# Altium schematic sheet MOTOR_DVR.SchDoc
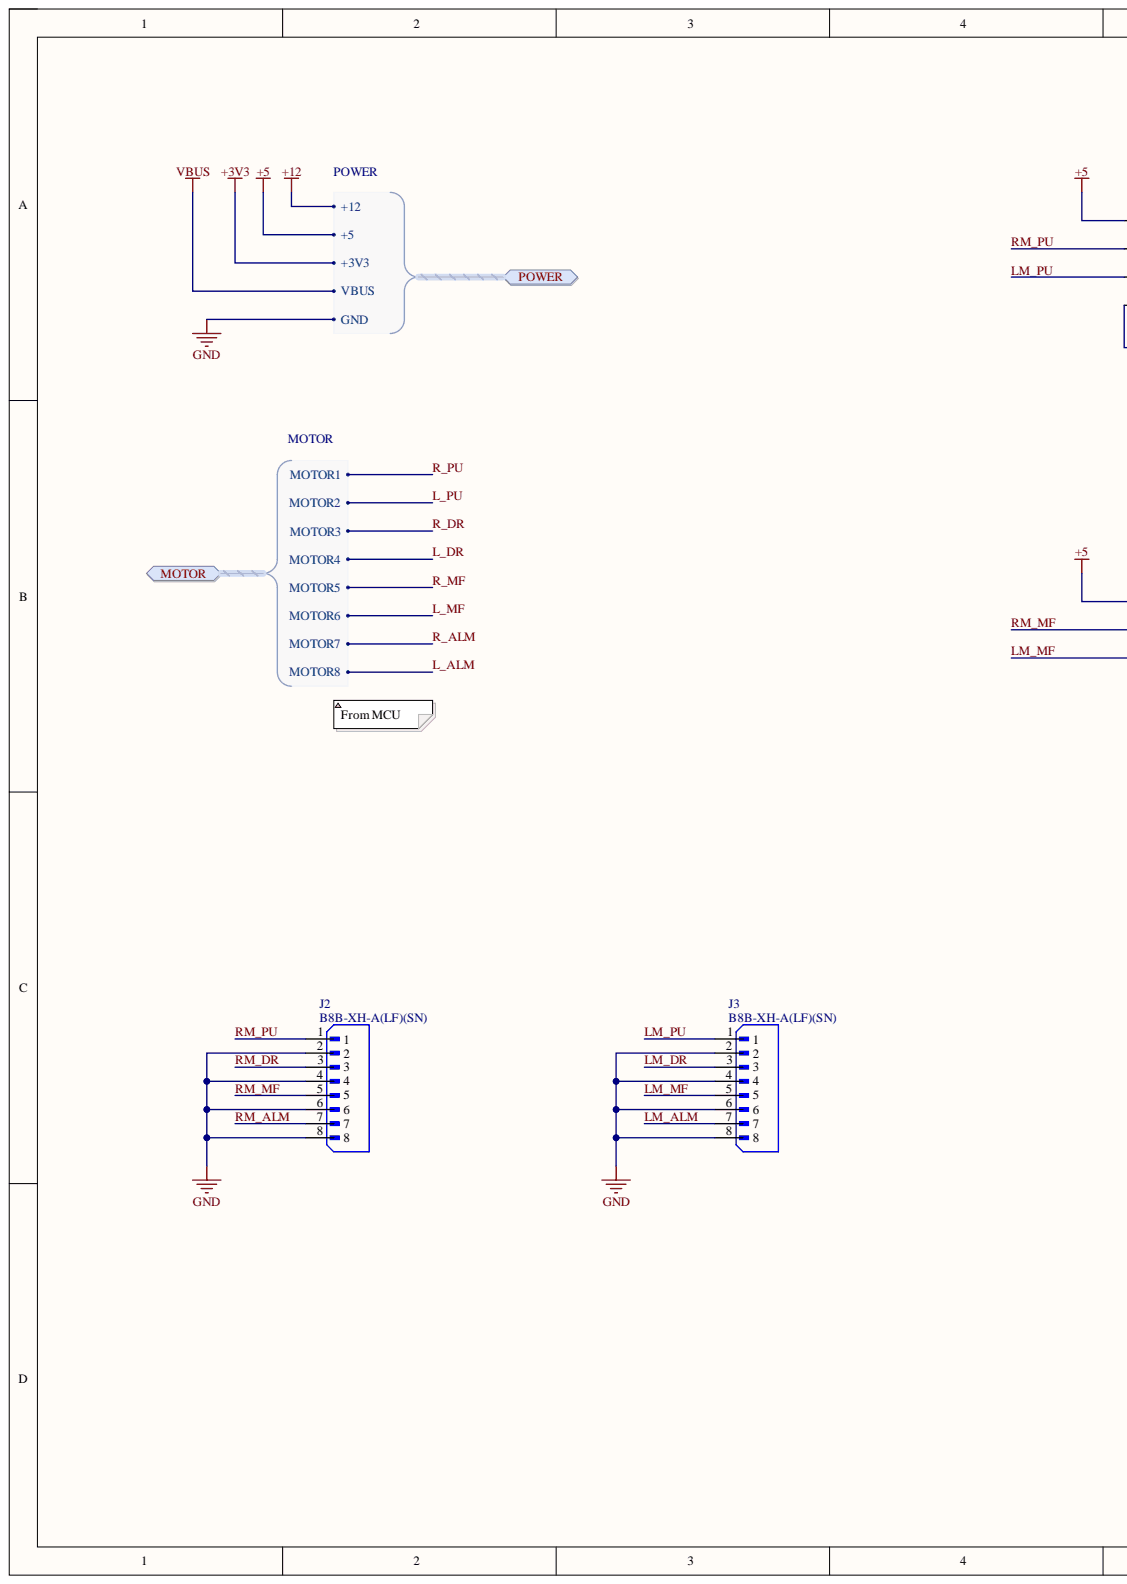
[diagram p0: MOTOR_DVR.SchDoc, left]
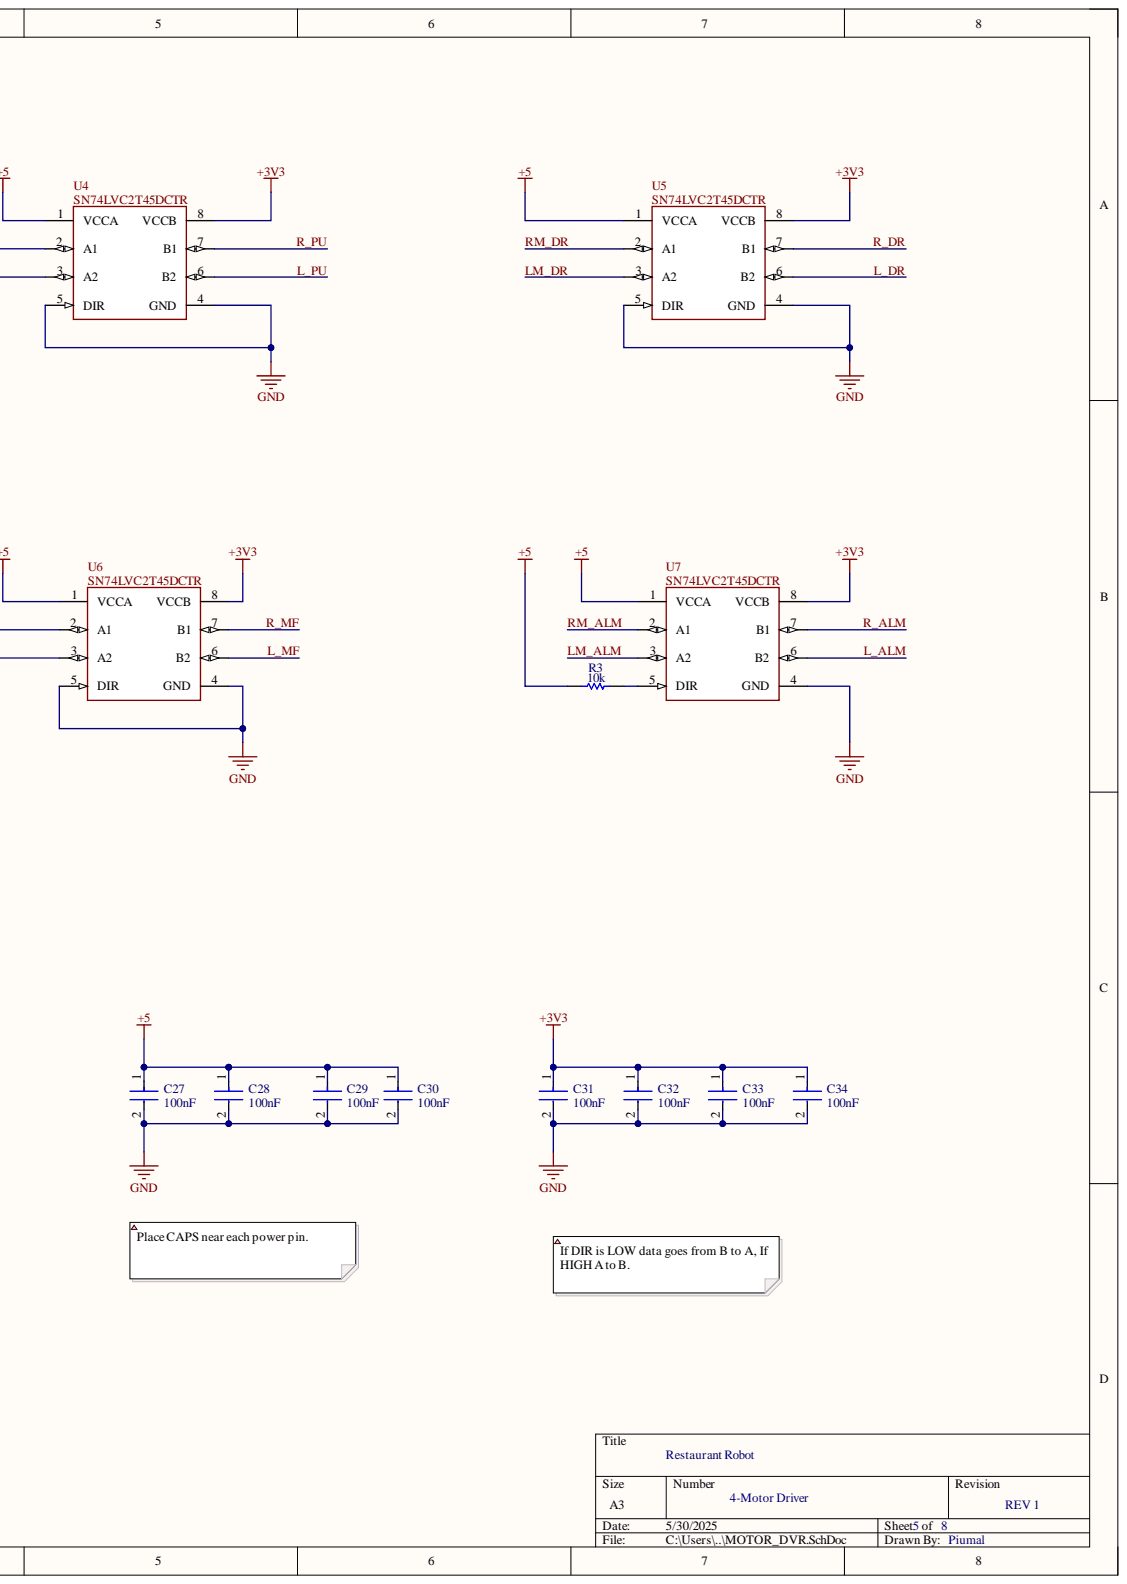
[diagram p1: MOTOR_DVR.SchDoc, right]

## Parts
C27  Capacitance: 100nF  [FP-CL21-IPC_A]  (hidden comment: CL21B104KOANNNC)
C28  Capacitance: 100nF  [FP-CL21-IPC_A]  (hidden comment: CL21B104KOANNNC)
C29  Capacitance: 100nF  [FP-CL21-IPC_A]  (hidden comment: CL21B104KOANNNC)
C30  Capacitance: 100nF  [FP-CL21-IPC_A]  (hidden comment: CL21B104KOANNNC)
C31  Capacitance: 100nF  [FP-CL21-IPC_A]  (hidden comment: CL21B104KOANNNC)
C32  Capacitance: 100nF  [FP-CL21-IPC_A]  (hidden comment: CL21B104KOANNNC)
C33  Capacitance: 100nF  [FP-CL21-IPC_A]  (hidden comment: CL21B104KOANNNC)
C34  Capacitance: 100nF  [FP-CL21-IPC_A]  (hidden comment: CL21B104KOANNNC)
J2  B8B-XH-A(LF)(SN)  [JST_B8B-XH-A(LF)(SN)]
J3  B8B-XH-A(LF)(SN)  [JST_B8B-XH-A(LF)(SN)]
R3  Resistance: 10k  [RESC2013X60X35ML10T20]  (hidden comment: RC0805JR-0710KL)
U4  SN74LVC2T45DCTR  [FP-DCT0008A-MFG]
U5  SN74LVC2T45DCTR  [FP-DCT0008A-MFG]
U6  SN74LVC2T45DCTR  [FP-DCT0008A-MFG]
U7  SN74LVC2T45DCTR  [FP-DCT0008A-MFG]

## Ports
MOTOR, MOTOR.MOTOR1, MOTOR.MOTOR2, MOTOR.MOTOR3, MOTOR.MOTOR4, MOTOR.MOTOR5, MOTOR.MOTOR6, MOTOR.MOTOR7, MOTOR.MOTOR8, POWER, POWER.+3V3, POWER.+5, POWER.+12, POWER.GND, POWER.VBUS

## Nets
+3V3: C31.1 C32.1 C33.1 C34.1 U4.8(VCCB) U5.8(VCCB) U6.8(VCCB) U7.8(VCCB) ; port POWER
+5: C27.1 C28.1 C29.1 C30.1 R3.1 U4.1(VCCA) U5.1(VCCA) U6.1(VCCA) U7.1(VCCA) ; port POWER
GND: C27.2 C28.2 C29.2 C30.2 C31.2 C32.2 C33.2 C34.2 J2.2 J2.4 J2.6 J2.8 J3.2 J3.4 J3.6 J3.8 U4.4(GND) U4.5(DIR) U5.4(GND) U5.5(DIR) U6.4(GND) U6.5(DIR) U7.4(GND) ; port POWER
L_ALM: U7.6(B2) ; port MOTOR
L_DR: U5.6(B2) ; port MOTOR
L_MF: U6.6(B2) ; port MOTOR
L_PU: U4.6(B2) ; port MOTOR
LM_ALM: J3.7 U7.3(A2)
LM_DR: J3.3 U5.3(A2)
LM_MF: J3.5 U6.3(A2)
LM_PU: J3.1 U4.3(A2)
R_ALM: U7.7(B1) ; port MOTOR
R_DR: U5.7(B1) ; port MOTOR
R_MF: U6.7(B1) ; port MOTOR
R_PU: U4.7(B1) ; port MOTOR
RM_ALM: J2.7 U7.2(A1)
RM_DR: J2.3 U5.2(A1)
RM_MF: J2.5 U6.2(A1)
RM_PU: J2.1 U4.2(A1)
NetR3_2: R3.2 U7.5(DIR)
+12: ; port POWER
VBUS: ; port POWER

## Library data of the parts
C27-C34 = CMP-13271-003679-1: CL21 Series 0805 100nF 16V ±10% Tolerance X7R Multilayer Ceramic Chip Capacitor; Case Code (Imperial): 0805; Case Code (Metric): 2012; Case/Package: 0805; Component Kind: Standard; Depth: 1.25mm; Dielectric: X7R; Height: 0.65mm; Lead Free: Lead Free; Length: 2mm; Library Name: Altium Content Vault; Max Operating Temperature: 125°C; Min Operating Temperature: -55°C; Mount: Surface Mount; Package Quantity: 1; Packaging: Tape and Reel; Radiation Hardening: No; Thickness: 0.03inch; Tolerance: 10%; Voltage Rating: 16V; Voltage Rating (DC): 16V; Width: 0.049inch
J2 J3 = B8B-XH-A(LF)(SN): Connector Header Through Hole 8 position 0.098 (2.50mm); MF: JST Sales America Inc.; Check_prices: https://www.snapeda.com/parts/B8B-XH-A(LF)(SN)/JST+Sales+America+Inc./view-part/?ref=eda; Component Kind: Standard; Library Name: B8B-XH-A_LF__SN_ (1).IntLib; MP: B8B-XH-A(LF)(SN); Package: None; SnapEDA_Link: https://www.snapeda.com/parts/B8B-XH-A(LF)(SN)/JST+Sales+America+Inc./view-part/?ref=snap
R3 = CMP-2000-05025-1: Chip Resistor, 10 KOhm, +/- 5%, 0.125 W, -55 to 155 degC, 0805 (2012 Metric), RoHS, Tape and Reel; Manufacturer: Yageo; Power Rating: 250mW; Product Range: RCSeries; Resistor Case Style: 0805[2012Metric]; Resistor Element Type: ThickFilm; SVHC: ToBeAdvised; Temperature Coefficient: ±100ppm/degC; Voltage Rating: 150V; Automotive Qualification Standard: -; Component Kind: Standard; Datasheet URL: http://www.yageo.ru/pdf/RC0805.pdf; Datasheet Version: REV. 2, 09/2004; Library Name: Altium Content Vault; Manufacturer URL: http://www.yageo.com/; MSL: MSL1-Unlimited; Package Description: 2-Pin Surface Mounted Device, Body 2 x 1.25 mm; Package Reference: 805; Packaging: CutTape; Resistance Tolerance: ±5%
U4-U7 = CMP-0859-00006-5: IC TRNSLTR BIDIRECTIONAL SM8; Manufacturer: Texas Instruments; Data Rate: 420Mbits/s; Logic Function Desc: Non-Inverting; Max Junction Temp: +150°C; Max Supply Voltage: 5.5V; Min Supply Voltage: 1.65V; Nominal Supply Current: 2-50uA; Number Of Circuits: 1; Standoff Height: 0mm; Ambient Temperature Range High: +85°C; Ambient Temperature Range Low: -40°C; Automotive: No; Category: IC; Component Kind: Standard; ComponentLink2Description: Datasheet; ComponentLink2URL: http://www.ti.com/lit/ds/symlink/sn74lvc2t45.pdf; Device Class L1: Integrated Circuits (ICs); Device Class L2: Logic ICs; Device Class L3: Voltage Level Shifters; Digikey Description: IC TRNSLTR BIDIRECTIONAL SM8; Height: 1.3mm; Ipc Land Pattern Name: SOP65P400X130-8; Lead Free: Yes; Library Name: Altium Content Vault; Manufacturer Part Number: SN74LVC2T45DCTR; Mouser Description: Translation - Voltage Levels DUAL-BIT DUAL SUPPLY TRANSCEIVER; Number Of Inputs: 1; Number Of Outputs: 1; Octopart: https://octopart.com/sn74lvc2t45dctr-texas+instruments-517051; Package: DCT0008A; RoHS: TRUE; Temperature: -40°C to +85°C; Temperature Range High: +85°C; Temperature Range Low: -40°C; V A Max: 5.5V; V A Min: 1.65V; V B Max: 5.5V; V B Min: 1.65V
